# Altium schematic sheet Sensors.SchDoc(Sensors)
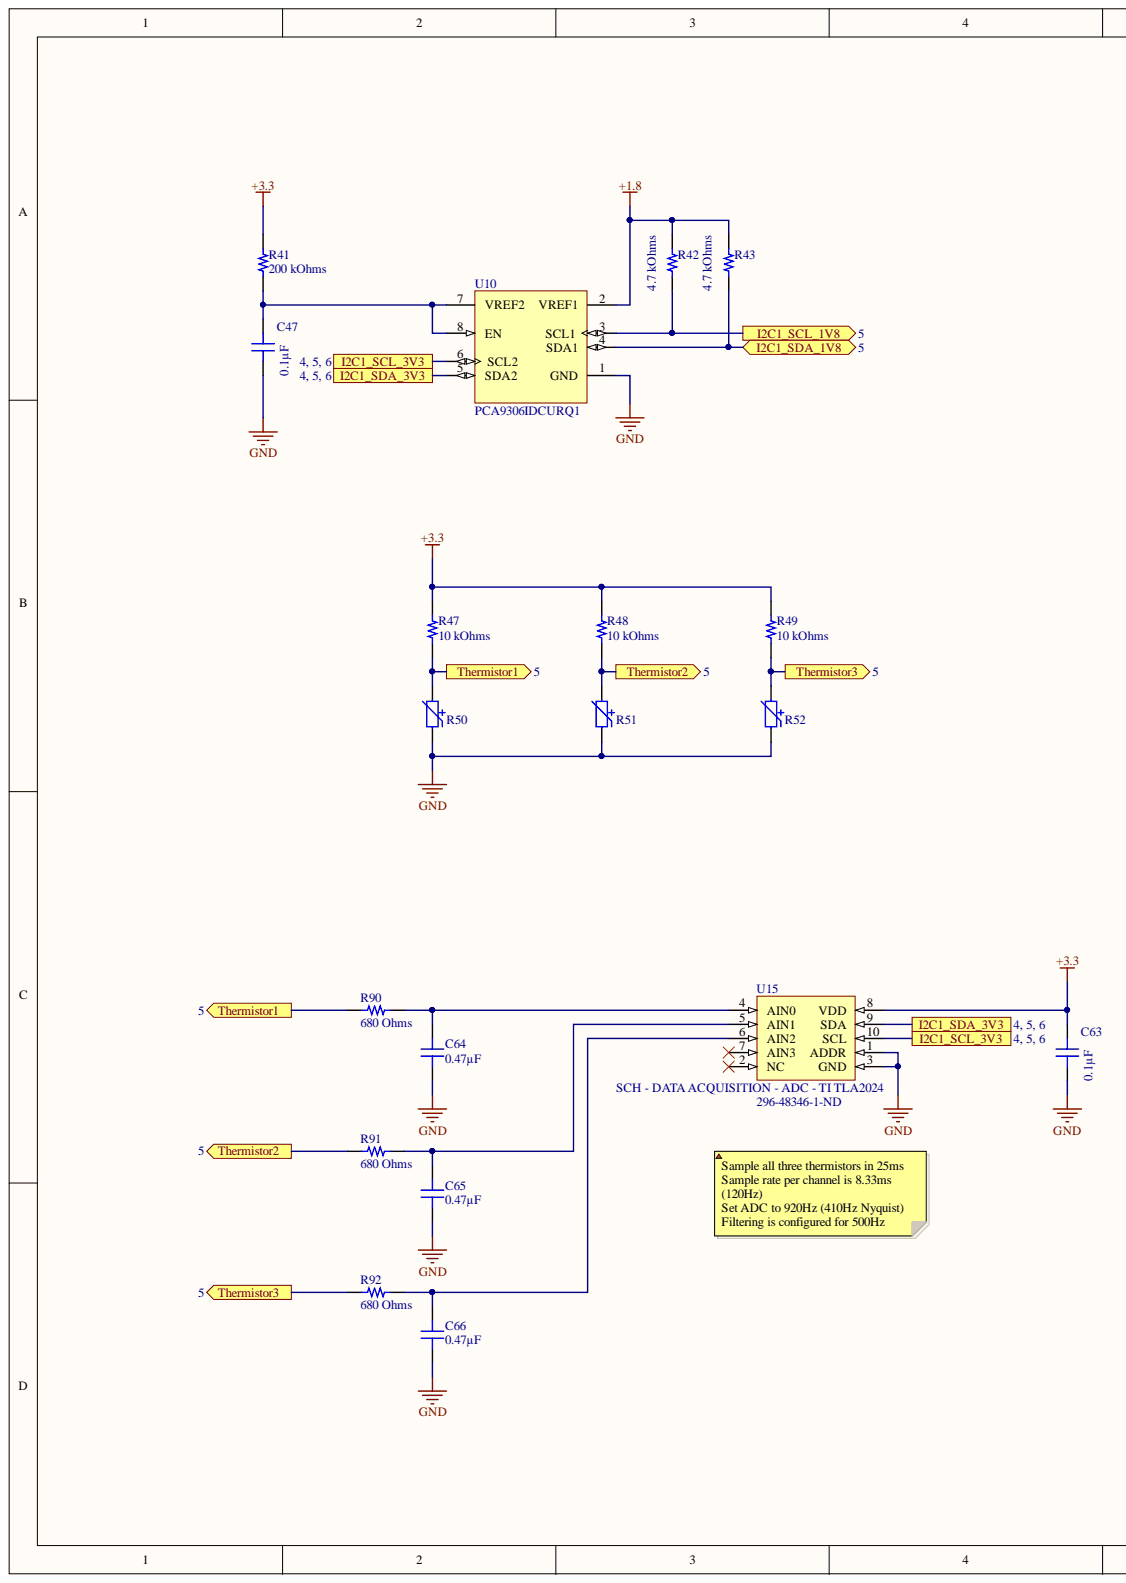
[diagram p0: Sensors.SchDoc, left]
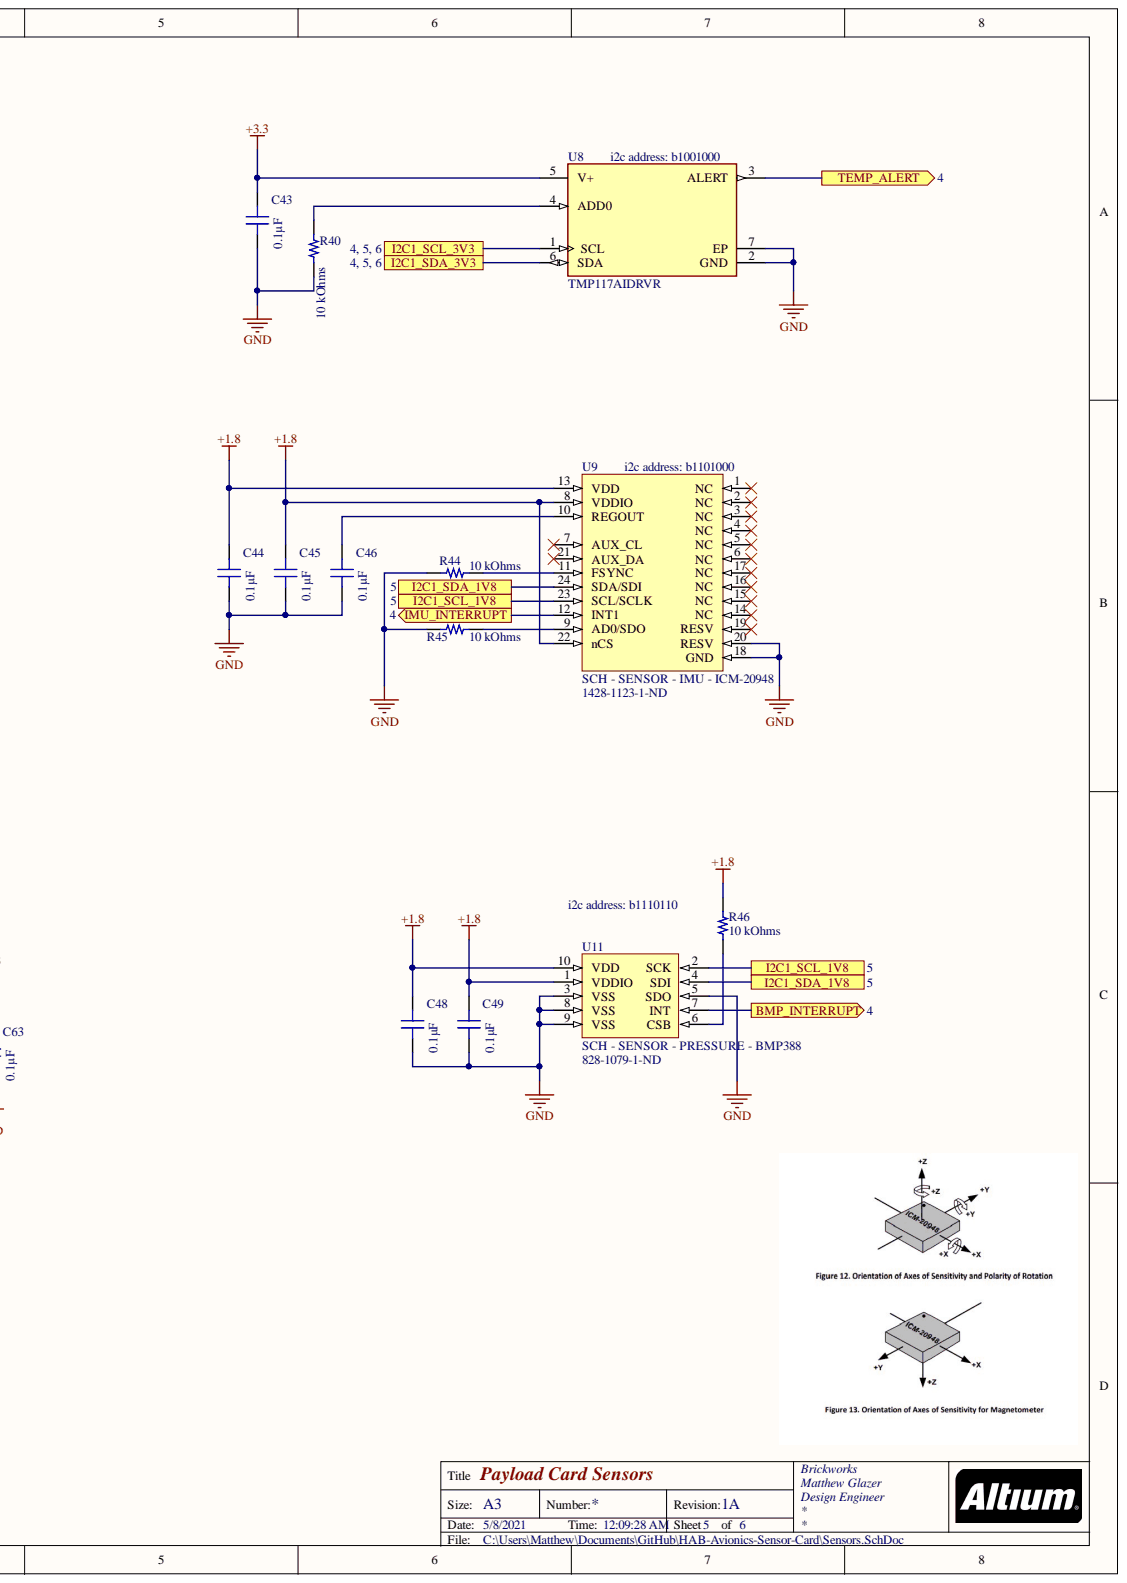
[diagram p1: Sensors.SchDoc, right]

## Parts
C43  0.1µF  [CAP 0603_1608]
C44  0.1µF  [CAP 0603_1608]
C45  0.1µF  [CAP 0603_1608]
C46  0.1µF  [CAP 0603_1608]
C47  0.1µF  [CAP 0603_1608]
C48  0.1µF  [CAP 0603_1608]
C49  0.1µF  [CAP 0603_1608]
C63  0.1µF  [CAP 0603_1608]
C64  0.47µF  [CAP 0603_1608]
C65  0.47µF  [CAP 0603_1608]
C66  0.47µF  [CAP 0603_1608]
R40  10 kOhms  [RES 0603_1608]
R41  200 kOhms  [RES 0603_1608]
R42  4.7 kOhms  [RES 0603_1608]
R43  4.7 kOhms  [RES 0603_1608]
R44  10 kOhms  [RES 0603_1608]
R45  10 kOhms  [RES 0603_1608]
R46  10 kOhms  [RES 0603_1608]
R47  10 kOhms  [RES 0603_1608]
R48  10 kOhms  [RES 0603_1608]
R49  10 kOhms  [RES 0603_1608]
R50  10 kOhms  [PTC 0402_1005]  (value not drawn)
R51  10 kOhms  [PTC 0402_1005]  (value not drawn)
R52  10 kOhms  [PTC 0402_1005]  (value not drawn)
R90  680 Ohms  [RES 0603_1608]
R91  680 Ohms  [RES 0603_1608]
R92  680 Ohms  [RES 0603_1608]
U8  TMP117AIDRVR  [FP-DRV0006A-MFG]
U9  SCH - SENSOR - IMU - ICM-20948  Supplier Part Number 1: 1428-1123-1-ND  [PCB - LEADLESS - QFN - TDK 24-TFQFN]
U10  PCA9306IDCURQ1  [DCU0008A_M]
U11  SCH - SENSOR - PRESSURE - BMP388  Supplier Part Number 1: 828-1079-1-ND  [PCB - LEADLESS - BOSCH LGA10]
U15  SCH - DATA ACQUISITION - ADC - TI TLA2024  Supplier Part Number 1: 296-48346-1-ND  [PCB - LEADLESS - QFN - TI X2QFN-10]

## Ports
BMP_INTERRUPT, I2C1_SCL_1V8, I2C1_SCL_3V3, I2C1_SDA_1V8, I2C1_SDA_3V3, IMU_INTERRUPT, TEMP_ALERT, Thermistor1, Thermistor2, Thermistor3

## Nets
+1.8: C44.2 C45.2 C48.2 C49.2 R42.2 R43.2 R46.1 U9.8(VDDIO) U9.13(VDD) U9.22(nCS) U10.2(VREF1) U11.1(VDDIO) U11.10(VDD)
+3.3: C43.2 C63.2 R41.2 R47.2 R48.2 R49.2 U8.5(V+) U15.8(VDD)
GND: C43.1 C44.1 C45.1 C46.1 C47.1 C48.1 C49.1 C63.1 C64.1 C65.1 C66.1 R40.1 R44.2 R45.2 R50.1 R51.1 R52.1 U8.2(GND) U8.7(EP) U9.18(GND) U9.20(RESV) U10.1(GND) U11.3(VSS) U11.5(SDO) U11.8(VSS) U11.9(VSS) U15.1(ADDR) U15.3(GND)
BMP_INTERRUPT: U11.7(INT) ; port BMP_INTERRUPT
I2C1_SCL_1V8: R42.1 U9.23(SCL/SCLK) U10.3(SCL1) U11.2(SCK) ; port I2C1_SCL_1V8
I2C1_SCL_3V3: U8.1(SCL) U10.6(SCL2) U15.10(SCL) ; port I2C1_SCL_3V3
I2C1_SDA_1V8: R43.1 U9.24(SDA/SDI) U10.4(SDA1) U11.4(SDI) ; port I2C1_SDA_1V8
I2C1_SDA_3V3: U8.6(SDA) U10.5(SDA2) U15.9(SDA) ; port I2C1_SDA_3V3
IMU_INTERRUPT: U9.12(INT1) ; port IMU_INTERRUPT
TEMP_ALERT: U8.3(ALERT) ; port TEMP_ALERT
Thermistor1: R47.1 R50.2 R90.1 ; port Thermistor1
Thermistor2: R48.1 R51.2 R91.1 ; port Thermistor2
Thermistor3: R49.1 R52.2 R92.1 ; port Thermistor3
NetC46_2: C46.2 U9.10(REGOUT)
NetC47_2: C47.2 R41.1 U10.7(VREF2) U10.8(EN)
NetC64_2: C64.2 R90.2 U15.4(AIN0)
NetC65_2: C65.2 R91.2 U15.5(AIN1)
NetC66_2: C66.2 R92.2 U15.6(AIN2)
NetR40_2: R40.2 U8.4(ADD0)
NetR44_1: R44.1 U9.11(FSYNC)
NetR45_1: R45.1 U9.9(AD0/SDO)
NetR46_2: R46.2 U11.6(CSB)

Not connected: U9 1(NC) 2(NC) 3(NC) 4(NC) 5(NC) 6(NC) 7(AUX_CL) 14(NC) 15(NC) 16(NC) 17(NC) 19(RESV) 21(AUX_DA); U15 2(NC) 7(AIN3)

## Library data of the parts
C43-C49 C63 = CL10B104KA8WPNC: CAP CER 0.1UF 25V X7R 0603; Manufacturer: Samsung Electro-Mechanics; Tolerance: ±10%; Database Table Name: Capacitors - Ceramic - 0603; Voltage - Rated: 25V; Value: 0.1µF; Thickness (Max): 0.035" (0.90mm); Temperature Coefficient: X7R; Supplier Part Number 1: 1276-6717-1-ND; Supplier 1: DigiKey; Size / Dimension: 0.063" L x 0.031" W (1.60mm x 0.80mm); Series: CL; Ratings: AEC-Q200; Price: 0.02; Part Status: Active; Part Number: CL10B104KA8WPNC; PartId: 5631; Packaging: TapeAndReel; Package / Case: 0603 (1608 Metric); Operating Temperature: -55°C ~ 125°C; Mounting Type: Surface Mount, MLCC; Minimum Order: 1; Lifecycle Status: Active; Library Name: Celestial Altium Library - altium_library.DbLib; LastUpdated: 9/2/2020 1:03:30 AM; Features: Open Mode; Device Package: 0603 (1608 Metric); ComponentLink2URL: http://digikey.com/product-detail/en/samsung-electro-mechanics/CL10B104KA8WPNC/1276-6717-1-ND/5961576; ComponentLink2Description: DigiKey Link; ComponentLink1URL: http://www.samsungsem.com/kr/support/product-search/mlcc/CL10B104KA8WPNC.jsp; ComponentLink1Description: Datasheet; Component Kind: Standard; Capacitance: 0.1µF; Applications: Automotive
C64-C66 = C0603C474K4PACTU: CAP CER 0.47UF 16V X5R 0603; Manufacturer: KEMET; Tolerance: ±10%; Database Table Name: Capacitors - Ceramic - 0603; Voltage - Rated: 16V; Value: 0.47µF; Thickness (Max): 0.034" (0.87mm); Temperature Coefficient: X5R; Supplier Part Number 1: 399-7934-1-ND; Supplier 1: DigiKey; Size / Dimension: 0.063" L x 0.031" W (1.60mm x 0.80mm); Series: SMD Comm X5R; Price: 0.02; Part Status: Active; Part Number: C0603C474K4PACTU; PartId: 2292; Packaging: TapeAndReel; Package / Case: 0603 (1608 Metric); Operating Temperature: -55°C ~ 85°C; Mounting Type: Surface Mount, MLCC; Minimum Order: 1; Lifecycle Status: Active; Library Name: Celestial Altium Library - altium_library.DbLib; LastUpdated: 9/2/2020 1:03:36 AM; Device Package: 0603 (1608 Metric); ComponentLink2URL: http://digikey.com/product-detail/en/kemet/C0603C474K4PACTU/399-7934-1-ND/3471657; ComponentLink2Description: DigiKey Link; ComponentLink1URL: https://api.kemet.com/component-edge/download/datasheet/C0603C474K4PACTU.pdf; ComponentLink1Description: Datasheet; Component Kind: Standard; Capacitance: 0.47µF; Applications: General Purpose
R40 R44-R46 = RMCF0603JT10K0: RES 10K OHM 5% 1/10W 0603; Manufacturer: Stackpole Electronics Inc; Temperature Coefficient: ±200ppm/°C; Power (Watts): 0.1W, 1/10W; Database Table Name: Resistors - Surface Mount - 0603; Value: 10 kOhms; Tolerance: ±5%; Supplier Part Number 1: RMCF0603JT10K0CT-ND; Supplier Device Package: 0603; Supplier 1: DigiKey; Size / Dimension: 0.061" L x 0.031" W (1.55mm x 0.80mm); Series: RMCF; Resistance: 10 kOhms; Ratings: AEC-Q200; Price: 0.10; Part Status: Active; Part Number: RMCF0603JT10K0; PartId: 25659; Packaging: CutTape; Package / Case: 0603 (1608 Metric); Operating Temperature: -55°C ~ 155°C; Number of Terminations: 2; Minimum Order: 1; Lifecycle Status: Active; Library Name: Celestial Altium Library - altium_library.DbLib; LastUpdated: 8/30/2020 8:48:45 PM; Height - Seated (Max): 0.022" (0.55mm); Features: Automotive AEC-Q200; Device Package: 0603 (1608 Metric); Composition: Thick Film; ComponentLink2URL: http://digikey.com/product-detail/en/stackpole-electronics-inc/RMCF0603JT10K0/RMCF0603JT10K0CT-ND/1943191; ComponentLink2Description: DigiKey Link; ComponentLink1URL: https://www.seielect.com/catalog/sei-packaging.pdf; ComponentLink1Description: Datasheet; Component Kind: Standard
R41 = RMCF0603FT200K: RES 200K OHM 1% 1/10W 0603; Manufacturer: Stackpole Electronics Inc; Temperature Coefficient: ±100ppm/°C; Power (Watts): 0.1W, 1/10W; Database Table Name: Resistors - Surface Mount - 0603; Value: 200 kOhms; Tolerance: ±1%; Supplier Part Number 1: RMCF0603FT200KCT-ND; Supplier Device Package: 0603; Supplier 1: DigiKey; Size / Dimension: 0.061" L x 0.031" W (1.55mm x 0.80mm); Series: RMCF; Resistance: 200 kOhms; Ratings: AEC-Q200; Price: 0.10; Part Status: Active; Part Number: RMCF0603FT200K; PartId: 10646; Packaging: CutTape; Package / Case: 0603 (1608 Metric); Operating Temperature: -55°C ~ 155°C; Number of Terminations: 2; Minimum Order: 1; Lifecycle Status: Active; Library Name: Celestial Altium Library - altium_library.DbLib; LastUpdated: 9/2/2020 1:10:13 AM; Height - Seated (Max): 0.022" (0.55mm); Features: Automotive AEC-Q200; Device Package: 0603 (1608 Metric); Composition: Thick Film; ComponentLink2URL: http://digikey.com/product-detail/en/stackpole-electronics-inc/RMCF0603FT200K/RMCF0603FT200KCT-ND/1943126; ComponentLink2Description: DigiKey Link; ComponentLink1URL: https://www.seielect.com/catalog/sei-rmcf_rmcp.pdf; ComponentLink1Description: Datasheet; Component Kind: Standard
R42 R43 = RMCF0603FT4K70: RES 4.7K OHM 1% 1/10W 0603; Manufacturer: Stackpole Electronics Inc; Temperature Coefficient: ±100ppm/°C; Power (Watts): 0.1W, 1/10W; Database Table Name: Resistors - Surface Mount - 0603; Value: 4.7 kOhms; Tolerance: ±1%; Supplier Part Number 1: RMCF0603FT4K70CT-ND; Supplier Device Package: 0603; Supplier 1: DigiKey; Size / Dimension: 0.061" L x 0.031" W (1.55mm x 0.80mm); Series: RMCF; Resistance: 4.7 kOhms; Ratings: AEC-Q200; Price: 0.10; Part Status: Active; Part Number: RMCF0603FT4K70; PartId: 6078; Packaging: CutTape; Package / Case: 0603 (1608 Metric); Operating Temperature: -55°C ~ 155°C; Number of Terminations: 2; Minimum Order: 1; Lifecycle Status: Active; Library Name: Celestial Altium Library - altium_library.DbLib; LastUpdated: 9/2/2020 1:10:22 AM; Height - Seated (Max): 0.022" (0.55mm); Features: Automotive AEC-Q200; Device Package: 0603 (1608 Metric); Composition: Thick Film; ComponentLink2URL: http://digikey.com/product-detail/en/stackpole-electronics-inc/RMCF0603FT4K70/RMCF0603FT4K70CT-ND/1943033; ComponentLink2Description: DigiKey Link; ComponentLink1URL: https://www.seielect.com/catalog/sei-rmcf_rmcp.pdf; ComponentLink1Description: Datasheet; Component Kind: Standard
R47-R49 = RMCF0603FT10K0: RES 10K OHM 1% 1/10W 0603; Manufacturer: Stackpole Electronics Inc; Temperature Coefficient: ±100ppm/°C; Power (Watts): 0.1W, 1/10W; Database Table Name: Resistors - Surface Mount - 0603; Value: 10 kOhms; Tolerance: ±1%; Supplier Part Number 1: RMCF0603FT10K0CT-ND; Supplier Device Package: 0603; Supplier 1: DigiKey; Size / Dimension: 0.061" L x 0.031" W (1.55mm x 0.80mm); Series: RMCF; Resistance: 10 kOhms; Ratings: AEC-Q200; Price: 0.10; Part Status: Active; Part Number: RMCF0603FT10K0; PartId: 25663; Packaging: CutTape; Package / Case: 0603 (1608 Metric); Operating Temperature: -55°C ~ 155°C; Number of Terminations: 2; Minimum Order: 1; Lifecycle Status: Active; Library Name: Celestial Altium Library - altium_library.DbLib; LastUpdated: 9/2/2020 1:09:31 AM; Height - Seated (Max): 0.022" (0.55mm); Features: Automotive AEC-Q200; Device Package: 0603 (1608 Metric); Composition: Thick Film; ComponentLink2URL: http://digikey.com/product-detail/en/stackpole-electronics-inc/RMCF0603FT10K0/RMCF0603FT10K0CT-ND/1943057; ComponentLink2Description: DigiKey Link; ComponentLink1URL: https://www.seielect.com/catalog/sei-rmcf_rmcp.pdf; ComponentLink1Description: Datasheet; Component Kind: Standard
R50-R52 = TMP6131DECR: SENSOR PTC 10KOHM 1% X1SON; Manufacturer: Texas Instruments; Database Table Name: Sensors - PTC Thermistors; Value: 10 kOhms; Supplier Part Number 1: 296-TMP6131DECRCT-ND; Supplier Device Package: 2-X1SON (1x.60); Supplier 1: DigiKey; Series: Commercial; Resistance Tolerance: ±1%; Resistance @ 25°C: 10 kOhms; Price: 0.07; Part Status: Active; Part Number: TMP6131DECR; Packaging: TapeAndReel; Package / Case: 0402 (1006 Metric); Operating Temperature: -40°C ~ 125°C (TA); Mounting Type: Surface Mount; Minimum Order: 1; Lifecycle Status: Active; Library Name: Celestial Altium Library - altium_library.DbLib; LastUpdated: 9/2/2020 1:30:57 AM; Device Package: 0402 (1006 Metric); ComponentLink2URL: http://digikey.com/product-detail/en/texas-instruments/TMP6131DECR/296-TMP6131DECRCT-ND/10435285; ComponentLink2Description: DigiKey Link; ComponentLink1URL: http://www.ti.com/general/docs/suppproductinfo.tsp?distId=10&gotoUrl=http%3A%2F%2Fwww.ti.com%2Flit%2Fgpn%2Ftmp61; ComponentLink1Description: Datasheet; Component Kind: Standard
R90-R92 = RMCF0603FT680R: RES 680 OHM 1% 1/10W 0603; Manufacturer: Stackpole Electronics Inc; Temperature Coefficient: ±100ppm/°C; Power (Watts): 0.1W, 1/10W; Database Table Name: Resistors - Surface Mount - 0603; Value: 680 Ohms; Tolerance: ±1%; Supplier Part Number 1: RMCF0603FT680RCT-ND; Supplier Device Package: 0603; Supplier 1: DigiKey; Size / Dimension: 0.061" L x 0.031" W (1.55mm x 0.80mm); Series: RMCF; Resistance: 680 Ohms; Ratings: AEC-Q200; Price: 0.10; Part Status: Active; Part Number: RMCF0603FT680R; PartId: 10671; Packaging: CutTape; Package / Case: 0603 (1608 Metric); Operating Temperature: -55°C ~ 155°C; Number of Terminations: 2; Minimum Order: 1; Lifecycle Status: Active; Library Name: Celestial Altium Library - altium_library.DbLib; LastUpdated: 9/2/2020 1:10:13 AM; Height - Seated (Max): 0.022" (0.55mm); Features: Automotive AEC-Q200; Device Package: 0603 (1608 Metric); Composition: Thick Film; ComponentLink2URL: http://digikey.com/product-detail/en/stackpole-electronics-inc/RMCF0603FT680R/RMCF0603FT680RCT-ND/6053170; ComponentLink2Description: DigiKey Link; ComponentLink1URL: https://www.seielect.com/catalog/sei-packaging.pdf; ComponentLink1Description: Datasheet; Component Kind: Standard
U8 = CMP-04948-000009-1: TEMPERATURE SENSOR; Manufacturer: Texas Instruments; Standoff Height: 0mm; Resolution: 16bits; Operating Supply Current: 3.5-16uA; Min Supply Voltage: 1.8V; Max Supply Voltage: 5.5V; Max Junction Temp: +150°C; Interface: I2C,2-Wire,SMBus; Temperature Range Low: -55°C; Temperature Range High: +150°C; Temperature: -55°C to +150°C; RoHS: TRUE; Package: DRV0006A; Octopart: https://octopart.com/tmp117aidrvr-texas+instruments-95511044; Manufacturer Part Number: TMP117AIDRVR; Library Name: Altium Content Vault; Lead Free: Yes; Ipc Land Pattern Name: DFN200X200X80-6; Height: 0.8mm; Digikey Description: TEMPERATURE SENSOR; Device Class L3: unset; Device Class L2: Temperature and Humidity Sensors; Device Class L1: Sensors; ComponentLink2URL: http://www.ti.com/lit/ds/symlink/tmp117.pdf; ComponentLink2Description: Datasheet; Component Kind: Standard; Category: IC; Automotive: No; Ambient Temperature Range Low: -55°C; Ambient Temperature Range High: +150°C
U10 = CMP-0314-00424-2: Automotive Catalog Dual Bidirectional I2C Bus and SMBus Voltage-Level Translator, 0 to 5.5 V,-40 to 85 degC,8-pin US8 (DCU), Green (RoHS & no Sb/Br); Manufacturer: Texas Instruments; Vcc range(V): 0 to 5.5; tpd max(ns): 1.4; SubFamily: I2C Special Function; Open Drain I/O Type: Yes; No. of Outputs: 2; Max Frequency(kHz): 400; Function: Voltage Translator; Rating: Automotive; PartNumber: PCA9306IDCURQ1; PackageVersion: revF, Jul-2005; PackageReference: DCU0008A; PackageDescription: 8-Pin Thin Shrink Small Outline Package 0.5 mm Pitch; On-Chip Power-on-Reset: No; Mounting Technology: Surface Mount; Library Name: Altium Content Vault; fSCLK(Max)(MHz): 400; Enable Pin: Yes; Eco-Plan: Green (RoHS & no Sb/Br); DatasheetVersion: SCPS178; ComponentLink2URL: http://www.ti.com/litv/pdf/mpds050d; ComponentLink2Description: Package Specification; ComponentLink1URL: http://www.ti.com/general/docs/lit/getliterature.tsp?genericPartNumber=PCA9306-Q1&fileType=pdf; ComponentLink1Description: Datasheet; Component Kind: Standard; Code_JEDEC: MO-187-CA; 5V Tolerant I/O: Yes
U9 = SCH - SENSOR - IMU - ICM-20948: Supplier 1: Digi-Key; Component Kind: Standard; Library Name: HAB_ICs.SchLib
U11 = SCH - SENSOR - PRESSURE - BMP388: Supplier 1: Digi-Key; Component Kind: Standard; Library Name: HAB_ICs.SchLib
U15 = SCH - DATA ACQUISITION - ADC - TI TLA2024: Supplier 1: Digi-Key; Component Kind: Standard; Library Name: HAB_ICs.SchLib
